# Altium schematic sheet drvoutput2.SchDoc(drvoutput2)
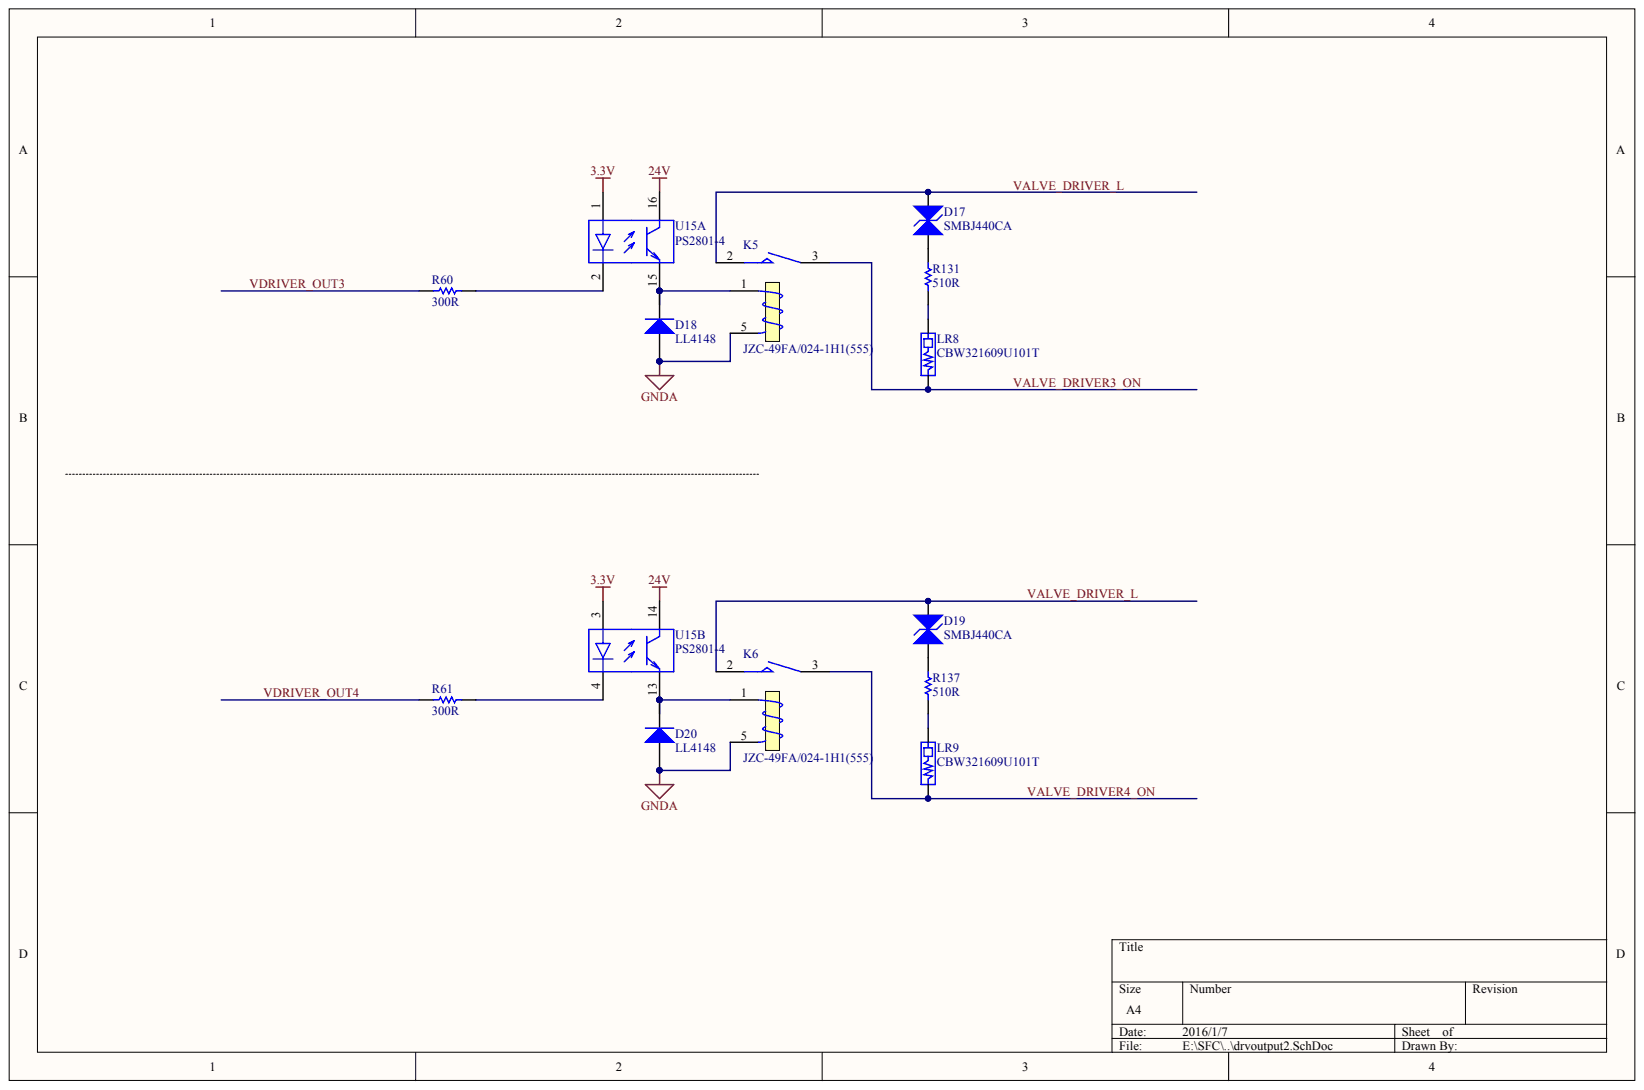
[diagram p0: drvoutput2.SchDoc]

## Parts
D17  SMBJ440CA
D18  LL4148
D19  SMBJ440CA
D20  LL4148
K5  JZC-49FA/024-1H1(555)
K6  JZC-49FA/024-1H1(555)
LR8  CBW321609U101T
LR9  CBW321609U101T
R60  300R
R61  300R
R131  510R
R137  510R
U15  PS2801-4  (parts A,B)

## Nets
3.3V: U15.1 U15.3
24V: U15.14 U15.16
GNDA: D18.1 D20.1 K5.5 K6.5
VALVE_DRIVER3_ON: K5.3 LR8.1
VALVE_DRIVER4_ON: K6.3 LR9.1
VALVE_DRIVER_L: D17.2 D19.2 K5.2 K6.2
VDRIVER_OUT3: R60.1
VDRIVER_OUT4: R61.1
NetD17_1: D17.1 R131.2
NetD18_2: D18.2 K5.1 U15.15
NetD19_1: D19.1 R137.2
NetD20_2: D20.2 K6.1 U15.13
NetLR8_2: LR8.2 R131.1
NetLR9_2: LR9.2 R137.1
NetR60_2: R60.2 U15.2
NetR61_2: R61.2 U15.4

## Library data of the parts
R60 R61 R131 R137 = (no symbol name): Value: 10K; Published: 8-Jun-2000; LatestRevisionDate: 17-Jul-2002; LatestRevisionNote: Re-released for DXP Platform.; PackageReference: AXIAL-0.3; Publisher: Altium Limited
D17-D20 K5 K6 LR8 LR9 U15 = (no symbol name)
  D17 D19: Published: 8-Jun-2000; LatestRevisionDate: 17-Jul-2002; LatestRevisionNote: Re-released for DXP Platform.; PackageReference: DIODE-0.7
  D18 D20: Published: 8-Jun-2000; LatestRevisionDate: 17-Jul-2002; LatestRevisionNote: Re-released for DXP Platform.; DatasheetVersion: 1998; PackageVersion: Aug-1999; PackageReference: DO-41; Code_JEDEC: DO-204-AL; ComponentLink1Description: Manufacturer Link; ComponentLink1URL: http://www.fairchildsemi.com/; ComponentLink2Description: Datasheet; ComponentLink2URL: http://www.fairchildsemi.com/ds/1N%2F1N4001.pdf
  K5 K6: Published: 8-Jun-2000; LatestRevisionDate: 17-Jul-2002; LatestRevisionNote: Re-released for DXP Platform.; PackageReference: MODULE5B
  U15: Published: 28-Feb-2003; LatestRevisionDate: 13-Apr-2006; LatestRevisionNote: IPC-7351 Footprint Added.; DatasheetVersion: Jan-2002, Rev. 7; PackageVersion: rev7, Jan-2002; PackageReference: 751-07; PackageDescription: 8-Pin Plastic Small Outline Integrated Circuit 1.27 mm Pitch; Code_JEDEC: MS-012-AA; Code_IPC: SOIC127P600-8; ComponentLink1Description: Manufacturer Link; ComponentLink1URL: http://www.onsemi.com/; ComponentLink2Description: Datasheet; ComponentLink2URL: http://www.onsemi.com/pub/Collateral/LM358-D.PDF
  D17-D20 K5 K6 U15: Publisher: Altium Limited
